# Altium schematic sheet Rifqi.SchDoc(Rifqi)
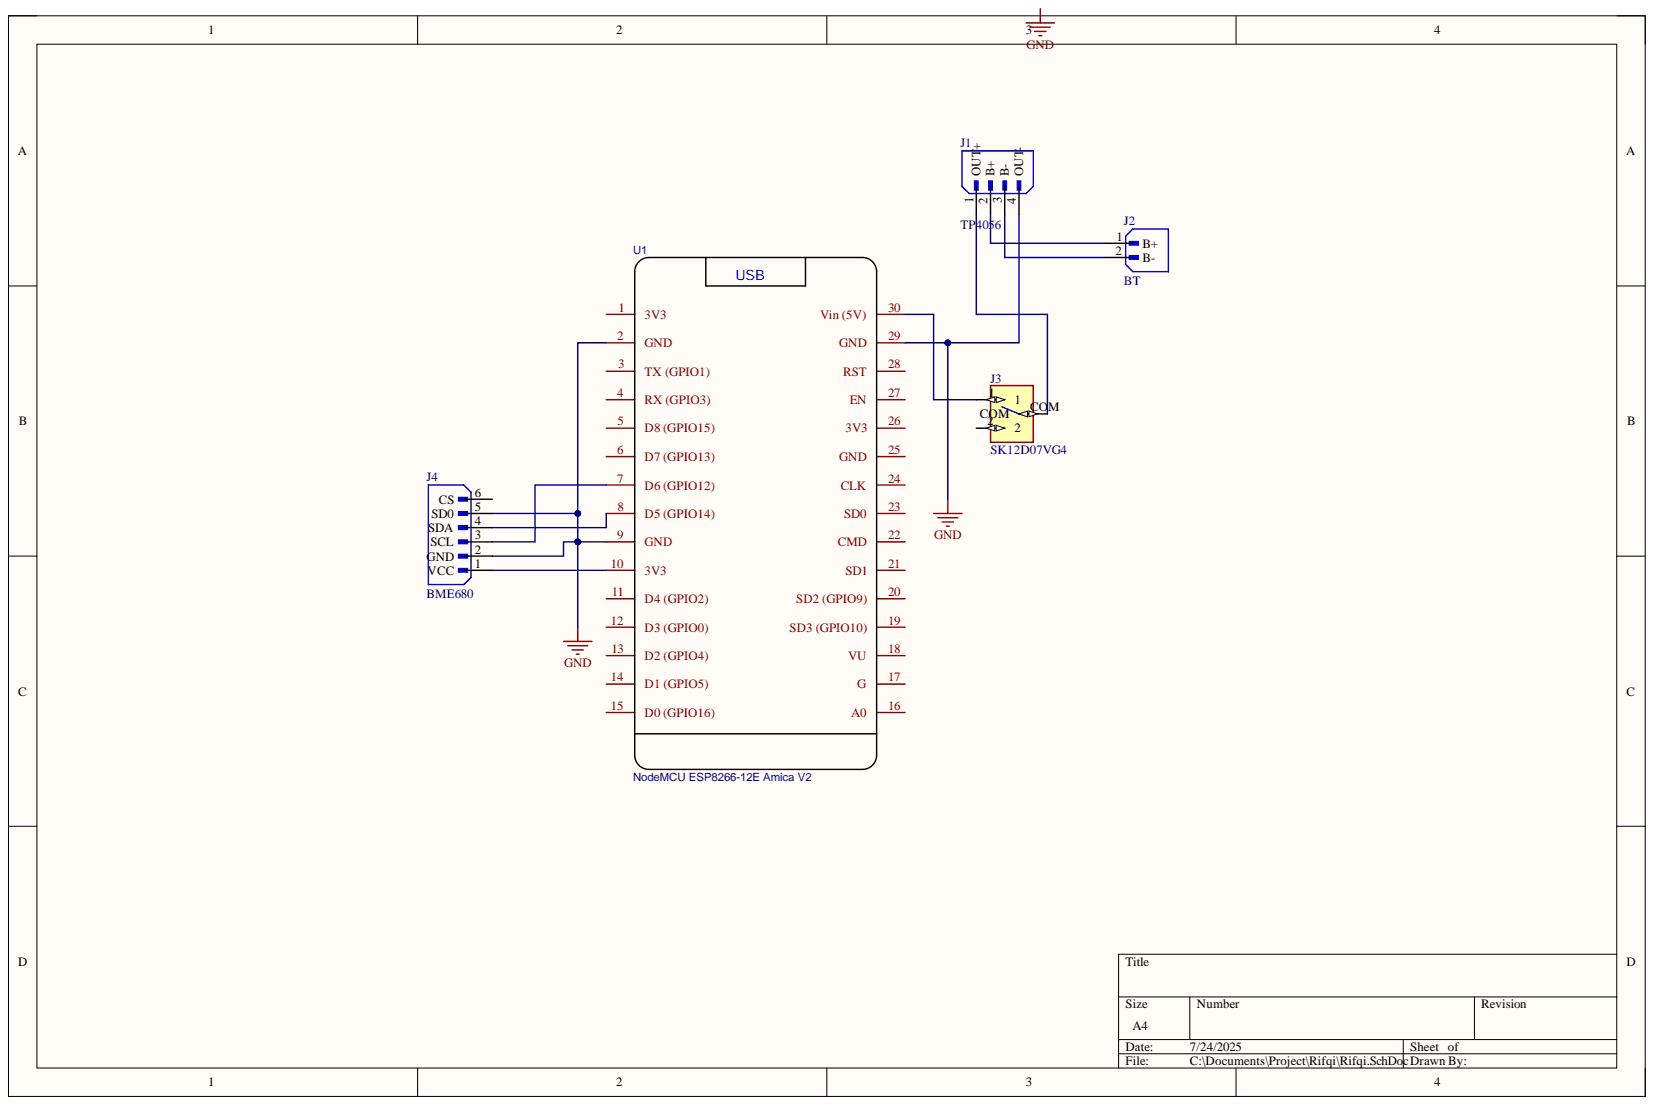
[diagram p0: Rifqi.SchDoc]

## Parts
J1  TP4056  [TP4056]
J2  BT  [2P JST]
J3  SK12D07VG4  [SK12D07VG4]
J4  BME680  [BME680]
U1  NodeMCU ESP8266-12E Amica V2  [ESP8266]

## Nets
GND: J1.4(OUT-) J4.2(GND) J4.5(SD0) U1.2(GND) U1.9(GND) U1.29(GND)
NetJ1_1: J1.1 J3.COM
NetJ1_2: J1.2(B+) J2.1
NetJ1_3: J1.3(B-) J2.2
NetJ3_1: J3.1 U1.30(Vin_(5V))
NetJ4_1: J4.1(VCC) U1.10(3V3)
NetJ4_3: J4.3(SCL) U1.7(D6_(GPIO12))
NetJ4_4: J4.4(SDA) U1.8(D5_(GPIO14))

Not connected: J3 2; J4 6(CS); U1 1(3V3) 3(TX_(GPIO1)) 4(RX_(GPIO3)) 5(D8_(GPIO15)) 6(D7_(GPIO13)) 11(D4_(GPIO2)) 12(D3_(GPIO0)) 13(D2_(GPIO4)) 14(D1_(GPIO5)) 15(D0_(GPIO16)) 16(A0) 17(G) 18(VU) 19(SD3_(GPIO10)) 20(SD2_(GPIO9)) 21(SD1) 22(CMD) 23(SD0) 24(CLK) 25(GND) 26(3V3) 27(EN) 28(RST)

## Library data of the parts
J1 = TP4056: Connector Header Through Hole 4 position 0.098 (2.50mm); MF: JST Sales; MP: B4B-XH-A(LF)(SN); Check_prices: https://www.snapeda.com/parts/B4B-XH-A(LF)(SN)/JST+Sales+America+Inc./view-part/?ref=eda; Component Kind: Standard; Package: None; SnapEDA_Link: https://www.snapeda.com/parts/B4B-XH-A(LF)(SN)/JST+Sales+America+Inc./view-part/?ref=snap; Library Name: Rifqi.SchLib
J2 = BT: Connector Header Through Hole 2 position 0.098 (2.50mm); MF: JST Sales; MP: B2B-XH-A(LF)(SN); Check_prices: https://www.snapeda.com/parts/B2B-XH-A(LF)(SN)/JST/view-part/?ref=eda; Component Kind: Standard; Package: None; SnapEDA_Link: https://www.snapeda.com/parts/B2B-XH-A(LF)(SN)/JST/view-part/?ref=snap; Library Name: Rifqi.SchLib
J3 = SK12D07VG4: Switch Slide ON ON SPDT Side Slide 0.3A 30VDC PC Pins Bracket Mount/Through Hole Bulk; MF: C&K; Check_prices: https://www.snapeda.com/parts/SK12D07VG4/C%2526K/view-part/?ref=eda; Component Kind: Standard; MP: SK12D07VG4; Package: None; SnapEDA_Link: https://www.snapeda.com/parts/SK12D07VG4/C%2526K/view-part/?ref=snap; Library Name: Rifqi.SchLib
J4 = BME680: Connector Header Through Hole 6 position 0.098 (2.50mm); MF: JST Sales; MP: B6B-XH-A(LF)(SN); Check_prices: https://www.snapeda.com/parts/B6B-XH-A(LF)(SN)/JST+Sales+America+Inc./view-part/?ref=eda; Component Kind: Standard; Package: None; SnapEDA_Link: https://www.snapeda.com/parts/B6B-XH-A(LF)(SN)/JST+Sales+America+Inc./view-part/?ref=snap; Library Name: Rifqi.SchLib
U1 = ESP8266: Contributor: Horacio Mauro Aquino; sourceId: c4ASM4mEW; Component Kind: Standard; spicePre: U; spiceSymbolName: NodeMCU ESP8266-12E Amica V2; Supplier: Nettigo; Supplier Part: nodemcu-v2; timeStamp: 1474282571; Author: Krzysztof Daszuta; Library Name: Rifqi.SchLib
